# Altium schematic sheet ECU_QGSM1.SchDoc
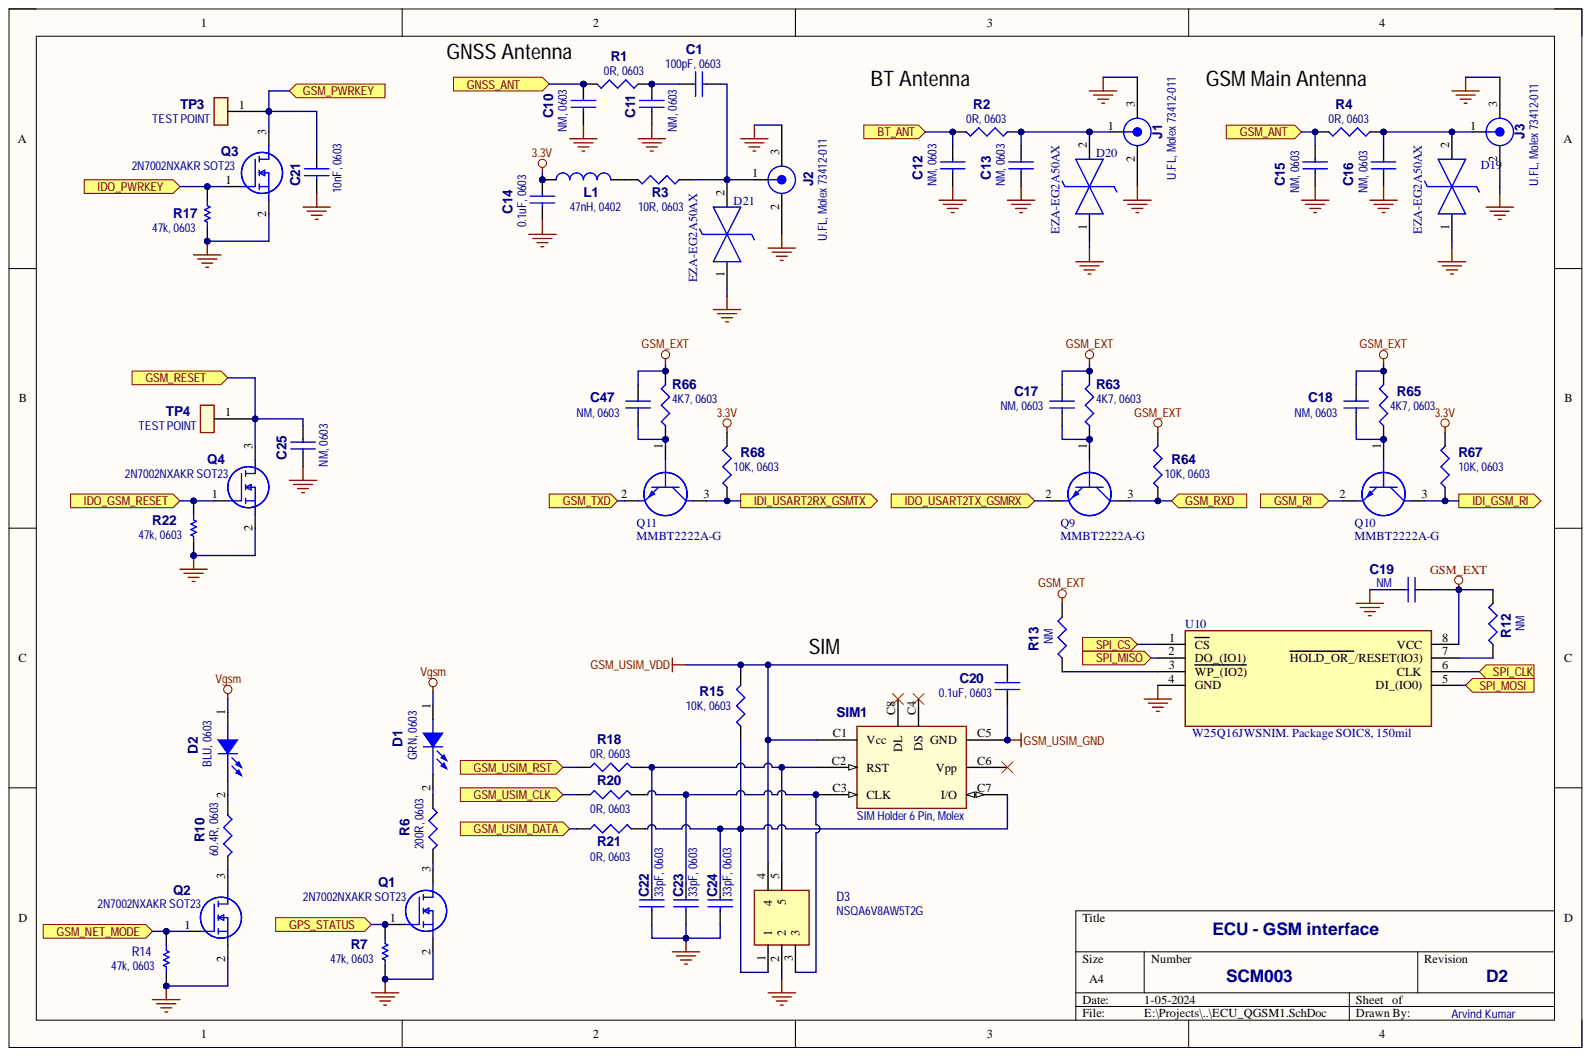
[diagram p0: ECU_QGSM1.SchDoc]

## Parts
C1  100pF, 0603  [C0603]
C10  NM, 0603  [C0603]
C11  NM, 0603  [C0603]
C12  NM, 0603  [C0603]
C13  NM, 0603  [C0603]
C14  0.1uF, 0603  [C0603]
C15  NM, 0603  [C0603]
C16  NM, 0603  [C0603]
C17  NM, 0603  [C0603]
C18  NM, 0603  [C0603]
C19  NM  [C0603]
C20  0.1uF, 0603  [C0603]
C21  10nF, 0603  [C0603]
C22  33pF, 0603  [C0603]
C23  33pF, 0603  [C0603]
C24  33pF, 0603  [C0603]
C25  NM, 0603  [C0603]
C47  NM, 0603  [C0603]
D1  GRN, 0603  [LED0603]
D2  BLU, 0603  [LED0603]
D3  NSQA6V8AW5T2G  [NSQA6V8AW5T2G]
D19  EZA-EG2A50AX  [EZAEG2A50AX]
D20  EZA-EG2A50AX  [EZAEG2A50AX]
D21  EZA-EG2A50AX  [EZAEG2A50AX]
J1  U.FL, Molex 73412-011  [U.FL, Molex 73412-011]
J2  U.FL, Molex 73412-011  [U.FL, Molex 73412-011]
J3  U.FL, Molex 73412-011  [U.FL, Molex 73412-011]
L1  47nH, 0402  [L0402]
Q1  2N7002NXAKR SOT23  [2N7002NXAKR]
Q2  2N7002NXAKR SOT23  [2N7002NXAKR]
Q3  2N7002NXAKR SOT23  [2N7002NXAKR]
Q4  2N7002NXAKR SOT23  [2N7002NXAKR]
Q9  MMBT2222A-G  [SOT95P240X115-3N]
Q10  MMBT2222A-G  [SOT95P240X115-3N]
Q11  MMBT2222A-G  [SOT95P240X115-3N]
R1  0R, 0603  [R0603]
R2  0R, 0603  [R0603]
R3  10R, 0603  [R0603]
R4  0R, 0603  [R0603]
R6  200R, 0603  [R0603]
R7  47k, 0603  [R0603]
R10  60.4R, 0603  [R0603]
R12  NM  [R0603]
R13  NM  [R0603]
R14  47k, 0603  [R0603]
R15  10K, 0603  [R0603]
R17  47k, 0603  [R0603]
R18  0R, 0603  [R0603]
R20  0R, 0603  [R0603]
R21  0R, 0603  [R0603]
R22  47k, 0603  [R0603]
R63  4K7, 0603  [R0603]
R64  10K, 0603  [R0603]
R65  4K7, 0603  [R0603]
R66  4K7, 0603  [R0603]
R67  10K, 0603  [R0603]
R68  10K, 0603  [R0603]
SIM1  SIM Holder 6 Pin, Molex  [SIM1]
TP3  TEST POINT  [Test Point SMD 1.5mm]
TP4  TEST POINT  [Test Point SMD 1.5mm]
U10  W25Q16JWSNIM. Package SOIC8, 150mil  [W25Q16JWSNIM]

## Ports
BT_ANT, GNSS_ANT, GPS_STATUS, GSM_ANT, GSM_NET_MODE, GSM_PWRKEY, GSM_RESET, GSM_RI, GSM_RXD, GSM_TXD, GSM_USIM_CLK, GSM_USIM_DATA, GSM_USIM_RST, IDI_GSM_RI, IDI_USART2RX_GSMTX, IDO_GSM_RESET, IDO_PWRKEY, IDO_USART2TX_GSMRX, SPI_CLK, SPI_CS, SPI_MISO, SPI_MOSI

## Nets
3.3V: C14.1 L1.1 R67.2 R68.2
GND_POWER: C10.2 C11.2 C12.2 C13.2 C14.2 C15.2 C16.2 C19.2 C21.2 C22.2 C23.2 C24.2 C25.2 D3.2 D19.1 D20.1 D21.1 J1.2 J1.3 J2.2 J2.3 J3.2 J3.3 Q1.2 Q2.2 Q3.2 Q4.2 R7.1 R14.1 R17.1 R22.1 U10.4(GND)
GSM_EXT: C17.1 C18.1 C19.1 C47.1 R12.2 R13.2 R63.2 R64.2 R65.2 R66.2 U10.8(VCC)
GSM_USIM_GND: C20.1 SIM1.C5(GND)
GSM_USIM_VDD: C20.2 D3.4 R15.2 SIM1.C1(Vcc)
Vgsm: D1.1 D2.1
BT_ANT: C12.1 R2.2 ; port BT_ANT
GNSS_ANT: C10.1 R1.2 ; port GNSS_ANT
GPS_STATUS: Q1.1 R7.2 ; port GPS_STATUS
GSM_ANT: C15.1 R4.2 ; port GSM_ANT
GSM_NET_MODE: Q2.1 R14.2 ; port GSM_NET_MODE
GSM_PWRKEY: C21.1 Q3.3 TP3.1 ; port GSM_PWRKEY
GSM_RESET: C25.1 Q4.3 TP4.1 ; port GSM_RESET
GSM_RI: Q10.2 ; port GSM_RI
GSM_RXD: Q9.3 R64.1 ; port GSM_RXD
GSM_TXD: Q11.2 ; port GSM_TXD
GSM_USIM_CLK: R20.1 ; port GSM_USIM_CLK
GSM_USIM_DATA: R21.1 ; port GSM_USIM_DATA
GSM_USIM_RST: R18.1 ; port GSM_USIM_RST
IDI_GSM_RI: Q10.3 R67.1 ; port IDI_GSM_RI
IDI_USART2RX_GSMTX: Q11.3 R68.1 ; port IDI_USART2RX_GSMTX
IDO_GSM_RESET: Q4.1 R22.2 ; port IDO_GSM_RESET
IDO_PWRKEY: Q3.1 R17.2 ; port IDO_PWRKEY
IDO_USART2TX_GSMRX: Q9.2 ; port IDO_USART2TX_GSMRX
SPI_CLK: U10.6(CLK) ; port SPI_CLK
SPI_CS: U10.1(CS) ; port SPI_CS
SPI_MISO: U10.2(DO_(IO1)) ; port SPI_MISO
SPI_MOSI: U10.5(DI_(IO0)) ; port SPI_MOSI
NetC1_1: C1.1 C11.1 R1.1
NetC1_2: C1.2 D21.2 J2.1 R3.1
NetC13_1: C13.1 D20.2 J1.1 R2.1
NetC16_1: C16.1 D19.2 J3.1 R4.1
NetC17_2: C17.2 Q9.1 R63.1
NetC18_2: C18.2 Q10.1 R65.1
NetC22_1: C22.1 D3.5 R18.2 SIM1.C2(RST)
NetC23_1: C23.1 D3.3 R20.2 SIM1.C3(CLK)
NetC24_1: C24.1 D3.1 R15.1 R21.2 SIM1.C7(I/O)
NetC47_2: C47.2 Q11.1 R66.1
NetD1_2: D1.2 R6.2
NetD2_2: D2.2 R10.2
NetL1_2: L1.2 R3.2
NetQ1_3: Q1.3 R6.1
NetQ2_3: Q2.3 R10.1
NetR12_1: R12.1 U10.7(HOLD_OR_/RESET(IO3))
NetR13_1: R13.1 U10.3(WP_(IO2))

Not connected: SIM1 C4(DS) C6(Vpp) C8(DL)

## Library data of the parts
C1 C10-C13 C15-C19 C47 = CAP NP_Dup1: Component Kind: Standard
C14 C20-C25 = CAP NP_Dup2: Component Kind: Standard
D1 D2 = LED_Dup1: Component Kind: Standard
D3 = NSQA6V8AW5T2G: Diode; Manufacturer_Name: ON Semiconductor; Arrow Part Number: NSQA6V8AW5T2G; Arrow Price/Stock: https://www.arrow.com/en/products/nsqa6v8aw5t2g/on-semiconductor; Component Kind: Standard; Datasheet Link: http://www.onsemi.com/pub/Collateral/NSQA6V8AW5T2-D.PDF; Height: 1.1mm; Manufacturer_Part_Number: NSQA6V8AW5T2G; Mouser Part Number: 863-NSQA6V8AW5T2G; Mouser Price/Stock: https://www.mouser.co.uk/ProductDetail/ON-Semiconductor/NSQA6V8AW5T2G?qs=ZXBb0xZ9WeDSeR92l0JbzA%3D%3D
D19-D21 = EZA-EG2A50AX: Diode ESD (Bi-directional); Manufacturer_Name: Panasonic; Arrow Part Number: EZA-EG2A50AX; Arrow Price/Stock: https://www.arrow.com/en/products/eza-eg2a50ax/panasonic; Component Kind: Standard; Datasheet Link: https://industrial.panasonic.com/cdbs/www-data/pdf/AWD0000/AWD0000C23.pdf; Height: 0.38mm; Manufacturer_Part_Number: EZA-EG2A50AX; Mouser Part Number: 667-EZA-EG2A50AX; Mouser Price/Stock: https://www.mouser.co.uk/ProductDetail/Panasonic/EZA-EG2A50AX?qs=WwqriLBepZuVGjkTEFYPQg%3D%3D; Simulation Data: https://industrial.panasonic.com/content/data/CC/files/EZAEG2A50AX.zip
J1-J3 = BNC_0: Component Kind: Standard
L1 = INDUCTOR: Component Kind: Standard
Q1-Q4 = 2N7002NXAKR: MOSFET (N-Channel); Manufacturer_Name: Nexperia; Arrow Part Number: 2N7002NXAKR; Arrow Price/Stock: https://www.arrow.com/en/products/2n7002nxakr/nexperia; Component Kind: Standard; Datasheet Link: https://mouser.componentsearchengine.com/Datasheets/2/2N7002NXAKR.pdf; Height: 1.1mm; Manufacturer_Part_Number: 2N7002NXAKR; Mouser Part Number: 771-2N7002NXAKR; Mouser Price/Stock: https://www.mouser.co.uk/ProductDetail/Nexperia/2N7002NXAKR?qs=%252B6g0mu59x7JoAsZYPFXqhw%3D%3D
Q9-Q11 = MMBT2222A-G: Transistor BJT NPN; Manufacturer_Name: Comchip Technology; Arrow Part Number: MMBT2222A-G; Arrow Price/Stock: https://www.arrow.com/en/products/mmbt2222a-g/comchip-technology?region=nac; Component Kind: Standard; Datasheet Link: https://datasheet.datasheetarchive.com/originals/distributors/Datasheets-7/DSA-138996.pdf; Height: 1.15mm; Manufacturer_Part_Number: MMBT2222A-G; Mouser Part Number: 750-MMBT2222A-G; Mouser Price/Stock: https://www.mouser.co.uk/ProductDetail/Comchip-Technology/MMBT2222A-G?qs=2qJf6qQ4IOIM%2FSE9iCL8gQ%3D%3D
R1-R4 R15 R18 R20 R21 = R_Dup2: Component Kind: Standard
R6 R10 R12 R13 R63-R68 = R_Dup1: Component Kind: Standard
R7 R14 R17 R22 = Res1: Resistor; Component Kind: Standard; LatestRevisionDate: 17-Jul-2002; LatestRevisionNote: Re-released for DXP Platform.; Library Name: Miscellaneous Devices.IntLib; PackageDescription: Axial Device, Thru-Hole; 2 Leads; 0.3 in Pin Spacing; PackageReference: AXIAL-0.3; Published: 8-Jun-2000; Signal Integrity: Res; Simulation: RESISTOR; Value: 47k; Publisher: Altium Limited
SIM1 = SIM_3: Component Kind: Standard
U10 = W25Q16JWZPIQ_TR: Integrated Circuit; Manufacturer_Name: Winbond; Manufacturer_Part_Number: W25Q16JWZPIQ TR; Component Kind: Standard; Datasheet Link: https://www.mouser.com/datasheet/2/949/W25Q16JW_RevD_01152020_Plus-1760324.pdf; Height: 0.8mm; Mouser Part Number: 454-W25Q16JWZPIQTR; Mouser Price/Stock: https://www.mouser.co.uk/ProductDetail/Winbond/W25Q16JWZPIQ-TR?qs=YwPsRIUVAOeRR88I4z6qow%3D%3D
TP3 TP4 = TEST POINT: Component Kind: Standard
